# Altium schematic sheet Peripherals.SchDoc
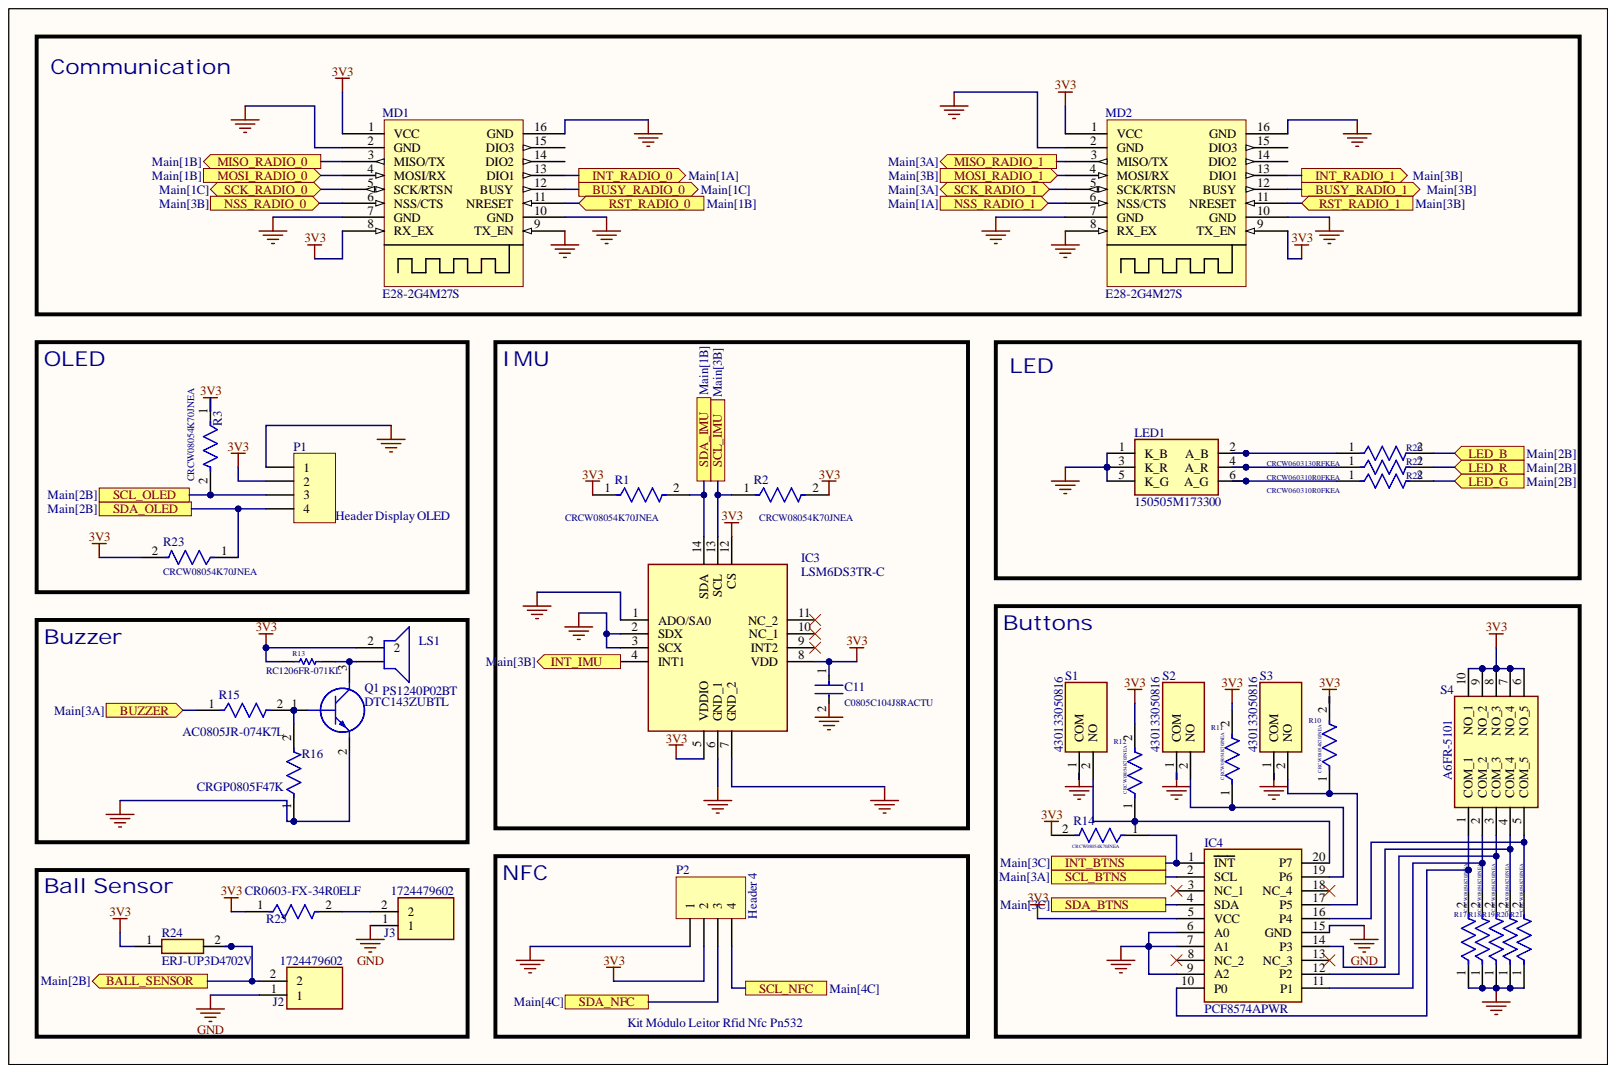
[diagram p0: Peripherals.SchDoc]

## Parts
C11  C0805C104J8RACTU  [FP-C0805C-DN-MFG]
IC3  LSM6DS3TR-C  [LGA-14(2.5X3X0.86)]
IC4  PCF8574APWR  [SOP65P640X120-20N]
J2  1724479602  [172447_94959697_02]
J3  1724479602  [172447_94959697_02]
LED1  150505M173300  [150505M173300]
LS1  PS1240P02BT  [PS1240P02BT]
MD1  E28-2G4M27S  [E28-2G4M27S]
MD2  E28-2G4M27S  [E28-2G4M27S]
P1  Header Display OLED  [HDR1X4]
P2  Header 4  [HDR1X4]
Q1  DTC143ZUBTL  [SOTFL65P210X105-3N]
R1  CRCW08054K70JNEA  [FP-CRCW0805-e3-IPC_B]
R2  CRCW08054K70JNEA  [FP-CRCW0805-e3-IPC_B]
R3  CRCW08054K70JNEA  [FP-CRCW0805-e3-IPC_B]
R10  CRCW08054K70JNEA  [FP-CRCW0805-e3-IPC_B]
R11  CRCW08054K70JNEA  [FP-CRCW0805-e3-IPC_B]
R12  CRCW08054K70JNEA  [FP-CRCW0805-e3-IPC_B]
R13  RC1206FR-071KL  [RESC3116X65X40ML10T20]
R14  CRCW08054K70JNEA  [FP-CRCW0805-e3-IPC_B]
R15  AC0805JR-074K7L  [FP-AC0805-MFG]
R16  CRGP0805F47K  [FP-CRGP0805-IPC_C]
R17  CRCW08054K70JNEA  [FP-CRCW0805-e3-IPC_B]
R18  CRCW08054K70JNEA  [FP-CRCW0805-e3-IPC_B]
R19  CRCW08054K70JNEA  [FP-CRCW0805-e3-IPC_B]
R20  CRCW08054K70JNEA  [FP-CRCW0805-e3-IPC_B]
R21  CRCW08054K70JNEA  [FP-CRCW0805-e3-IPC_B]
R23  CRCW08054K70JNEA  [FP-CRCW0805-e3-IPC_B]
R24  ERJ-UP3D4702V  [ERJUP3]
R25  CR0603-FX-34R0ELF  [FP-CR0603-MFG]
R26  CRCW0603130RFKEA  [FP-CRCW0603-e3-MFG]
R27  CRCW060310R0FKEA  [FP-CRCW0603-e3-IPC_A]
R28  CRCW060310R0FKEA  [FP-CRCW0603-e3-IPC_A]
S1  430133050816  [430133050816]
S2  430133050816  [430133050816]
S3  430133050816  [430133050816]
S4  A6FR-5101  [A6FR5101]

## Ports
BALL_SENSOR, BUSY_RADIO_0, BUSY_RADIO_1, BUZZER, INT_BTNS, INT_IMU, INT_RADIO_0, INT_RADIO_1, LED_B, LED_G, LED_R, MISO_RADIO_0, MISO_RADIO_1, MOSI_RADIO_0, MOSI_RADIO_1, NSS_RADIO_0, NSS_RADIO_1, RST_RADIO_0, RST_RADIO_1, SCK_RADIO_0, SCK_RADIO_1, SCL_BTNS, SCL_IMU, SCL_NFC, SCL_OLED, SDA_BTNS, SDA_IMU, SDA_NFC, SDA_OLED

## Nets
3V3: C11.1 IC3.5(VDDIO) IC3.8(VDD) IC3.12(CS) IC4.5(VCC) LS1.2 MD1.1(VCC) MD1.8(RX_EX) MD2.1(VCC) MD2.9(TX_EN) P1.2 P2.2 R1.1 R2.2 R3.1 R10.2 R11.2 R12.2 R13.1 R14.2 R23.2 R24.1 R25.1 S4.6(NO_5) S4.7(NO_4) S4.8(NO_3) S4.9(NO_2) S4.10(NO_1)
GND: C11.2 IC3.1(ADO/SA0) IC3.2(SDX) IC3.3(SCX) IC3.6(GND_1) IC3.7(GND_2) IC4.6(A0) IC4.7(A1) IC4.9(A2) IC4.15(GND) J2.1 J3.1 LED1.1(K_B) LED1.3(K_R) LED1.5(K_G) MD1.2(GND) MD1.7(GND) MD1.9(TX_EN) MD1.10(GND) MD1.16(GND) MD2.2(GND) MD2.7(GND) MD2.8(RX_EX) MD2.10(GND) MD2.16(GND) P1.1 P2.1 Q1.2 R16.1 R17.1 R18.1 R19.1 R20.1 R21.1 S1.1 S2.1 S3.1
NetLS1: LS1. Q1.3 R13.2
BALL_SENSOR: J2.2 R24.2 ; port BALL_SENSOR
BUSY_RADIO_0: MD1.12(BUSY) ; port BUSY_RADIO_0
BUSY_RADIO_1: MD2.12(BUSY) ; port BUSY_RADIO_1
BUZZER: R15.1 ; port BUZZER
INT_BTNS: IC4.1(INT) R14.1 ; port INT_BTNS
INT_IMU: IC3.4(INT1) ; port INT_IMU
INT_RADIO_0: MD1.13(DIO1) ; port INT_RADIO_0
INT_RADIO_1: MD2.13(DIO1) ; port INT_RADIO_1
LED_B: R26.2 ; port LED_B
LED_G: R28.2 ; port LED_G
LED_R: R27.2 ; port LED_R
MISO_RADIO_0: MD1.3(MISO/TX) ; port MISO_RADIO_0
MISO_RADIO_1: MD2.3(MISO/TX) ; port MISO_RADIO_1
MOSI_RADIO_0: MD1.4(MOSI/RX) ; port MOSI_RADIO_0
MOSI_RADIO_1: MD2.4(MOSI/RX) ; port MOSI_RADIO_1
NSS_RADIO_0: MD1.6(NSS/CTS) ; port NSS_RADIO_0
NSS_RADIO_1: MD2.6(NSS/CTS) ; port NSS_RADIO_1
RST_RADIO_0: MD1.11(NRESET) ; port RST_RADIO_0
RST_RADIO_1: MD2.11(NRESET) ; port RST_RADIO_1
SCK_RADIO_0: MD1.5(SCK/RTSN) ; port SCK_RADIO_0
SCK_RADIO_1: MD2.5(SCK/RTSN) ; port SCK_RADIO_1
SCL_BTNS: IC4.2(SCL) ; port SCL_BTNS
SCL_IMU: IC3.13(SCL) R2.1 ; port SCL_IMU
SCL_NFC: P2.4 ; port SCL_NFC
SCL_OLED: P1.3 R3.2 ; port SCL_OLED
SDA_BTNS: IC4.4(SDA) ; port SDA_BTNS
SDA_IMU: IC3.14(SDA) R1.2 ; port SDA_IMU
SDA_NFC: P2.3 ; port SDA_NFC
SDA_OLED: P1.4 R23.1 ; port SDA_OLED
NetIC4_10: IC4.10(P0) R17.2 S4.1(COM_1)
NetIC4_11: IC4.11(P1) R18.2 S4.2(COM_2)
NetIC4_12: IC4.12(P2) R19.2 S4.3(COM_3)
NetIC4_14: IC4.14(P3) R20.2 S4.4(COM_4)
NetIC4_16: IC4.16(P4) R21.2 S4.5(COM_5)
NetIC4_17: IC4.17(P5) R10.1 S3.2
NetIC4_19: IC4.19(P6) R11.1 S2.2
NetIC4_20: IC4.20(P7) R12.1 S1.2
NetJ3_2: J3.2 R25.2
NetLED1_2: LED1.2(A_B) R26.1
NetLED1_4: LED1.4(A_R) R27.1
NetLED1_6: LED1.6(A_G) R28.1
NetQ1_1: Q1.1 R15.2 R16.2

Not connected: IC3 9(INT2) 10(NC_1) 11(NC_2); IC4 3(NC_1) 8(NC_2) 13(NC_3) 18(NC_4); MD1 14(DIO2) 15(DIO3); MD2 14(DIO2) 15(DIO3)

## Library data of the parts
C11 = CMP-03020-032188-1: CAP CER 0.1UF 10V 5% X7R 0805; Manufacturer: KEMET; Capacitance: 0.10 μF; Material: Ceramic; Temperature Coefficient: 30ppm/°C; Tolerance: 0.05; Value: 0.10 μF; Automotive: No; Category: Cap; Component Kind: Standard; ComponentLink2Description: Datasheet; ComponentLink2URL: https://content.kemet.com/datasheets/KEM_C1003_C0G_SMD.pdf; Device Class L1: Passive Components; Device Class L2: Capacitors; Device Class L3: Ceramic Capacitors; Digikey Description: CAP CER 0.1UF 10V 5% X7R 0805; Height: 0.88mm; Ipc Land Pattern Name: CAPC200125X78; Lead Free: Yes; Library Name: Altium Content Vault; Manufacturer Part Number: C0805C104J8RACTU; Mouser Description: Multilayer Ceramic Capacitors MLCC - SMD/SMT 10volts 0.1uF X7R 5%; Octopart: https://octopart.com/c0805c104j8ractu-kemet-11807087; Package: C0805C-DN; RoHS: TRUE; Temperature: -55°C to +125°C; Temperature Characteristic: X7R; Temperature Range High: +125°C; Temperature Range Low: -55°C; Voltage Rating: 10 V
IC3 = LSM6DS3TR-C: Integrated Circuit; Manufacturer_Name: STMicroelectronics; Arrow Part Number: LSM6DS3TR-C; Arrow Price/Stock: https://www.arrow.com/en/products/lsm6ds3tr-c/stmicroelectronics?region=nac; Component Kind: Standard; Datasheet Link: http://www.st.com/resource/en/datasheet/lsm6ds3tr-c.pdf; Manufacturer_Part_Number: LSM6DS3TR-C; Mouser Part Number: 511-LSM6DS3TR-C; Mouser Price/Stock: https://www.mouser.co.uk/ProductDetail/STMicroelectronics/LSM6DS3TR-C?qs=u4fy%2FsgLU9NHwPlhP3LTBw%3D%3D; Library Name: roboime.SchLib
IC4 = PCF8574APWR: Integrated Circuit; Manufacturer_Name: Texas Instruments; Arrow Part Number: PCF8574APWR; Arrow Price/Stock: https://www.arrow.com/en/products/pcf8574apwr/texas-instruments?region=nac; Component Kind: Standard; Datasheet Link: http://www.ti.com/lit/ds/symlink/pcf8574a.pdf; Height: 1.2mm; Manufacturer_Part_Number: PCF8574APWR; Mouser Part Number: 595-PCF8574APWR; Mouser Price/Stock: https://www.mouser.co.uk/ProductDetail/Texas-Instruments/PCF8574APWR?qs=bkMXpVdiF42gbWVI8U3xeA%3D%3D
J2 J3 = 1724479602: Connector Mate 46992-0240; Manufacturer_Name: Molex; Component Kind: Standard; Datasheet Link: https://www.molex.com/pdm_docs/sd/1724470402_sd.pdf; Height: 12.8mm; Manufacturer_Part_Number: 1724479602; Library Name: roboime.SchLib
LED1 = 150505M173300: LED; Manufacturer_Name: Wurth Elektronik; Component Kind: Standard; Datasheet Link: https://katalog.we-online.de/led/datasheet/150505M173300.pdf; Height: 1.8mm; Manufacturer_Part_Number: 150505M173300; Mouser Part Number: 710-150505M173300; Mouser Price/Stock: https://www.mouser.co.uk/ProductDetail/Wurth-Elektronik/150505M173300?qs=fAHHVMwC%252Bbi%2FURn4Qu0%2FAA%3D%3D
LS1 = PS1240P02BT: Loudspeaker or Buzzer; Manufacturer_Name: TDK; Arrow Part Number: PS1240P02BT; Arrow Price/Stock: https://www.arrow.com/en/products/ps1240p02bt/tdk?region=nac; Component Kind: Standard; Datasheet Link: https://product.tdk.com/system/files/dam/doc/product/sw_piezo/sw_piezo/piezo-buzzer/catalog/piezoelectronic_buzzer_ps_en.pdf; Manufacturer_Part_Number: PS1240P02BT; Mouser Part Number: 810-PS1240P02BT; Mouser Price/Stock: https://www.mouser.co.uk/ProductDetail/TDK/PS1240P02BT?qs=d7g9p1yFhWaZXSY9MjKMkw%3D%3D; Library Name: roboime.SchLib
MD1 MD2 = E28-2G4M27S: E28-2G4M27S Ebyte SX1280 Module; Component Kind: Standard; Library Name: roboime.SchLib
P1 P2 = Header 4: Header, 4-Pin; Component Kind: Standard; LatestRevisionDate: 17-Jul-2002; LatestRevisionNote: Re-released for DXP Platform.; Library Name: Miscellaneous Connectors.IntLib; Signal Integrity: Connector; Publisher: Altium Limited
Q1 = DTC143ZUBTL: Transistor BJT NPN; Manufacturer_Name: ROHM Semiconductor; Component Kind: Standard; Datasheet Link: https://componentsearchengine.com/Datasheets/2/DTC143ZUBTL.pdf; Height: 1.05mm; Manufacturer_Part_Number: DTC143ZUBTL; Mouser Part Number: 755-DTC143ZUBTL; Mouser Price/Stock: https://www.mouser.co.uk/ProductDetail/ROHM-Semiconductor/DTC143ZUBTL?qs=IsRgwgmxh6%2Ffuj9yCN7zBQ%3D%3D
R1-R3 R10-R12 R14 R17-R21 R23 = CMP-2001-03967-2: RES Thick Film, 4.7kΩ, 5%, 0.125W, 200ppm/°C, 0805; Power Rating: 125mW; Resistance: 4.7kR; Voltage Rating: 150V; Case Code (Imperial): 0805; Case Code (Metric): 2012; Case/Package: 0805; Component Kind: Standard; Composition: Thick Film; Contact Plating: Tin; Depth: 1.25mm; Height: 0.45mm; Length: 2mm; Library Name: Altium Content Vault; Max Operating Temperature: 155°C; Military Standard: Not; Min Operating Temperature: -55°C; Mount: Surface Mount; Package Quantity: 5000; Packaging: Tape and Reel; Radiation Hardening: No; Temperature Coefficient: 200ppm/°C; Termination: SMD/SMT; Tolerance: 5%; Weight: 0.000194oz; Width: 1.25mm
R13 = CMP-2100-03678-1: Chip Resistor, 1 KOhm, +/- 1%, 0.25 W, -55 to 155 degC, 1206 (3216 Metric), RoHS, Tape and Reel; Manufacturer: Yageo; Power Rating: 250mW; Resistance: 1KOhm; Temperature Coefficient: 100ppm/degreesC; Voltage Rating: 200V; Case Code (Imperial): 1206; Case Code (Metric): 3216; Case/Package: 1206; Component Kind: Standard; Composition: ThickFilm; Datasheet URL: http://www.yageo.com/NewPortal/yageodocoutput?fileName=/pdf/R-Chip/PYu-RC_Group_51_RoHS_L_5.pdf; Datasheet Version: Rev. 5, 10/2016; Depth: 1.6mm; Height: 0.55mm; Lead Free: LeadFree; Length: 3.1mm; Library Name: Altium Content Vault; Manufacturer URL: http://www.yageo.com/; Max Operating Temperature: 155degC; Military Standard: Not; Min Operating Temperature: -55degC; Package Description: 2-Pin Surface Mount Device, Body 3.1 x 1.6 mm; Package Reference: 1206.0; Packaging: TapeandReel; REACH SVHC: Unknown; RoHSCompliant: true; Termination: SMD/SMT; Tolerance: 1%
R15 = CMP-2001-04476-2: RES SMD 4.7K OHM 5% 1/8W 0805; Manufacturer: Yageo; Automotive Grade: Grade 0; Resistance: 4.7KΩ; Tolerance: 0.05; Value: 4.7KΩ; Voltage Rating: 150V; Automotive: Yes; Category: Res; Component Kind: Standard; ComponentLink2Description: Datasheet; ComponentLink2URL: http://www.yageo.com/documents/recent/PYu-AC_51_RoHS_L_6.pdf; Composition: Thick Film; Device Class L1: Passive Components; Device Class L2: Resistors; Device Class L3: Chip SMD Resistors; Digikey Description: RES SMD 4.7K OHM 5% 1/8W 0805; Footprint Url: http://www.yageo.com/exep/pages/download/literatures/PYu-R_Mount_10.pdf; Height: 0.6mm; Ipc Land Pattern Name: RESC200125X50; Lead Free: Yes; Library Name: Altium Content Vault; Manufacturer Part Number: AC0805JR-074K7L; Mouser Description: Thick Film Resistors - SMD 1/8W 4.7K ohm 5% AEC-Q200; Octopart: https://octopart.com/ac0805jr-074k7l-yageo-26638632; Package: AC0805; Power Rating: 1/8W; RoHS: TRUE; Series: AC; Temperature: -55°C to +155°C; Temperature Range High: +155°C; Temperature Range Low: -55°C
R16 = CMP-03211-006358-1: CRGP0805 47KΩ 0.33W ±100ppm/°C 1% 150V; Manufacturer: TE; Tolerance: 0.01; Ambient Temperature Range High: +70°C; Automotive: No; Category: Res; Component Kind: Standard; ComponentLink2Description: Datasheet; ComponentLink2URL: https://www.mouser.com/datasheet/2/418/NG_DS_9-1773463-9_A-1358566.pdf; Composition: Thick Film; Device Class L1: Passive Components; Device Class L2: Resistors; Device Class L3: Chip SMD Resistors; Height: 0.65mm; Ipc Land Pattern Name: RESC200125X55; Lead Free: Yes; Library Name: Altium Content Vault; Manufacturer Part Number: CRGP0805F47K; Octopart: https://octopart.com/crgp0805f47k-te+connectivity-91018823; Package: CRGP0805; Power Rating: 0.33W; Resistance: 47KΩ; RoHS: TRUE; Series: CRGP; Temperature: -55°C to +155°C; Temperature Coefficient: ±100ppm/°C; Temperature Range High: +155°C; Temperature Range Low: -55°C; Value: 47KΩ; Voltage Rating: 150V
R24 = ERJ-UP3D4702V: Resistor; Manufacturer_Name: Panasonic; Component Kind: Standard; Datasheet Link: https://industrial.panasonic.com/cdbs/www-data/pdf/RDP0000/AOA0000C337.pdf; Height: 0.55mm; Manufacturer_Part_Number: ERJ-UP3D4702V; Mouser Part Number: 667-ERJ-UP3D4702V; Mouser Price/Stock: https://www.mouser.co.uk/ProductDetail/Panasonic/ERJ-UP3D4702V?qs=GedFDFLaBXG9rkg%252BckNv2g%3D%3D; Library Name: roboime.SchLib
R25 = CMP-1012-00343-2: Thick Film Chip Resistors 0603 34Ω 0.1W 1% 100ppm/°C; Power Rating: 100mW; Resistance: 34R; Voltage Rating: 50V; Case Code (Imperial): 0603; Case Code (Metric): 1608; Case/Package: 0603; Component Kind: Standard; Composition: Thick Film; Contact Plating: Tin; Depth: 0.8mm; Height: 0.45mm; Length: 1.6mm; Library Name: Altium Content Vault; Max Operating Temperature: 155°C; Military Standard: Not; Min Operating Temperature: -55°C; Mount: Surface Mount; Packaging: Tape and Reel; Radiation Hardening: No; Temperature Coefficient: 100ppm/°C; Termination: SMD/SMT; Thickness: 457um; Tolerance: 1%; Weight: 7.1E-05oz; Width: 0.8mm
R26 = CMP-2000-03889-2: RES Thick Film, 130Ω, 1%, 0.1W, 100ppm/°C, 0603; Max Power Dissipation: 100mW; Resistance: 130R; Voltage Rating: 75V; Case Code (Imperial): 0603; Case Code (Metric): 1608; Case/Package: 0603; Component Kind: Standard; Composition: Thick Film; Contact Plating: Tin; Depth: 0.85mm; Features: Automotive AEC-Q200; Height: 0.45mm; Lead Free: Lead Free; Length: 1.55mm; Library Name: Altium Content Vault; Max Operating Temperature: 155°C; Military Standard: Not; Min Operating Temperature: -55°C; Number of Pins: 2; Number of Terminations: 2; Package Quantity: 10; Packaging: Tape and Reel; Power Rating: 100mW; Radiation Hardening: No; Temperature Coefficient: 100ppm/°C; Termination: SMD/SMT; Tolerance: 1%; Voltage Rating (DC): 75V; Weight: 7.1E-05oz; Width: 0.85mm; Working Voltage: 75V
R27 R28 = CMP-2000-03845-2: RES Thick Film, 10Ω, 1%, 0.1W, 100ppm/°C, 0603; Max Power Dissipation: 100mW; Resistance: 10R; Voltage Rating: 75V; Case Code (Imperial): 0603; Case Code (Metric): 1608; Case/Package: 0603; Component Kind: Standard; Composition: Thick Film; Contact Plating: Tin; Depth: 0.85mm; Features: Automotive AEC-Q200; Height: 0.45mm; Lead Free: Lead Free; Length: 1.55mm; Library Name: Altium Content Vault; Max Operating Temperature: 155°C; Military Standard: Not; Min Operating Temperature: -55°C; Mount: Surface Mount; Number of Pins: 2; Number of Terminations: 2; Package Quantity: 10; Packaging: Tape and Reel; Power Rating: 100mW; Temperature Coefficient: 100ppm/°C; Termination: SMD/SMT; Tolerance: 1%; Voltage Rating (DC): 75V; Weight: 7.1E-05oz; Width: 0.033inch
S1-S3 = 430133050816: Switch; Manufacturer_Name: Wurth Elektronik; Component Kind: Standard; Datasheet Link: https://www.we-online.com/components/products/datasheet/430133050816.pdf; Height: 5.2mm; Manufacturer_Part_Number: 430133050816; Mouser Part Number: 710-430133050816; Mouser Price/Stock: https://www.mouser.co.uk/ProductDetail/Wurth-Elektronik/430133050816?qs=ufv2HEpFn%252BRy3i84BABEDg%3D%3D
S4 = A6FR-5101: Switch; Manufacturer_Name: Omron Electronics; Arrow Part Number: A6FR-5101; Arrow Price/Stock: https://www.arrow.com/en/products/a6fr5101/omron?region=nac; Component Kind: Standard; Datasheet Link: https://www.mouser.com/datasheet/2/307/omron_03202018_A6FR_datasheet-1314011.pdf; Height: 10.3mm; Manufacturer_Part_Number: A6FR-5101; Mouser Part Number: 653-A6FR-5101; Mouser Price/Stock: https://www.mouser.co.uk/ProductDetail/Omron-Electronics/A6FR-5101?qs=fAHHVMwC%252BbhmGWTU7%252Bb5wg%3D%3D
